# Altium schematic sheet RTL8189_WIFI.SchDoc
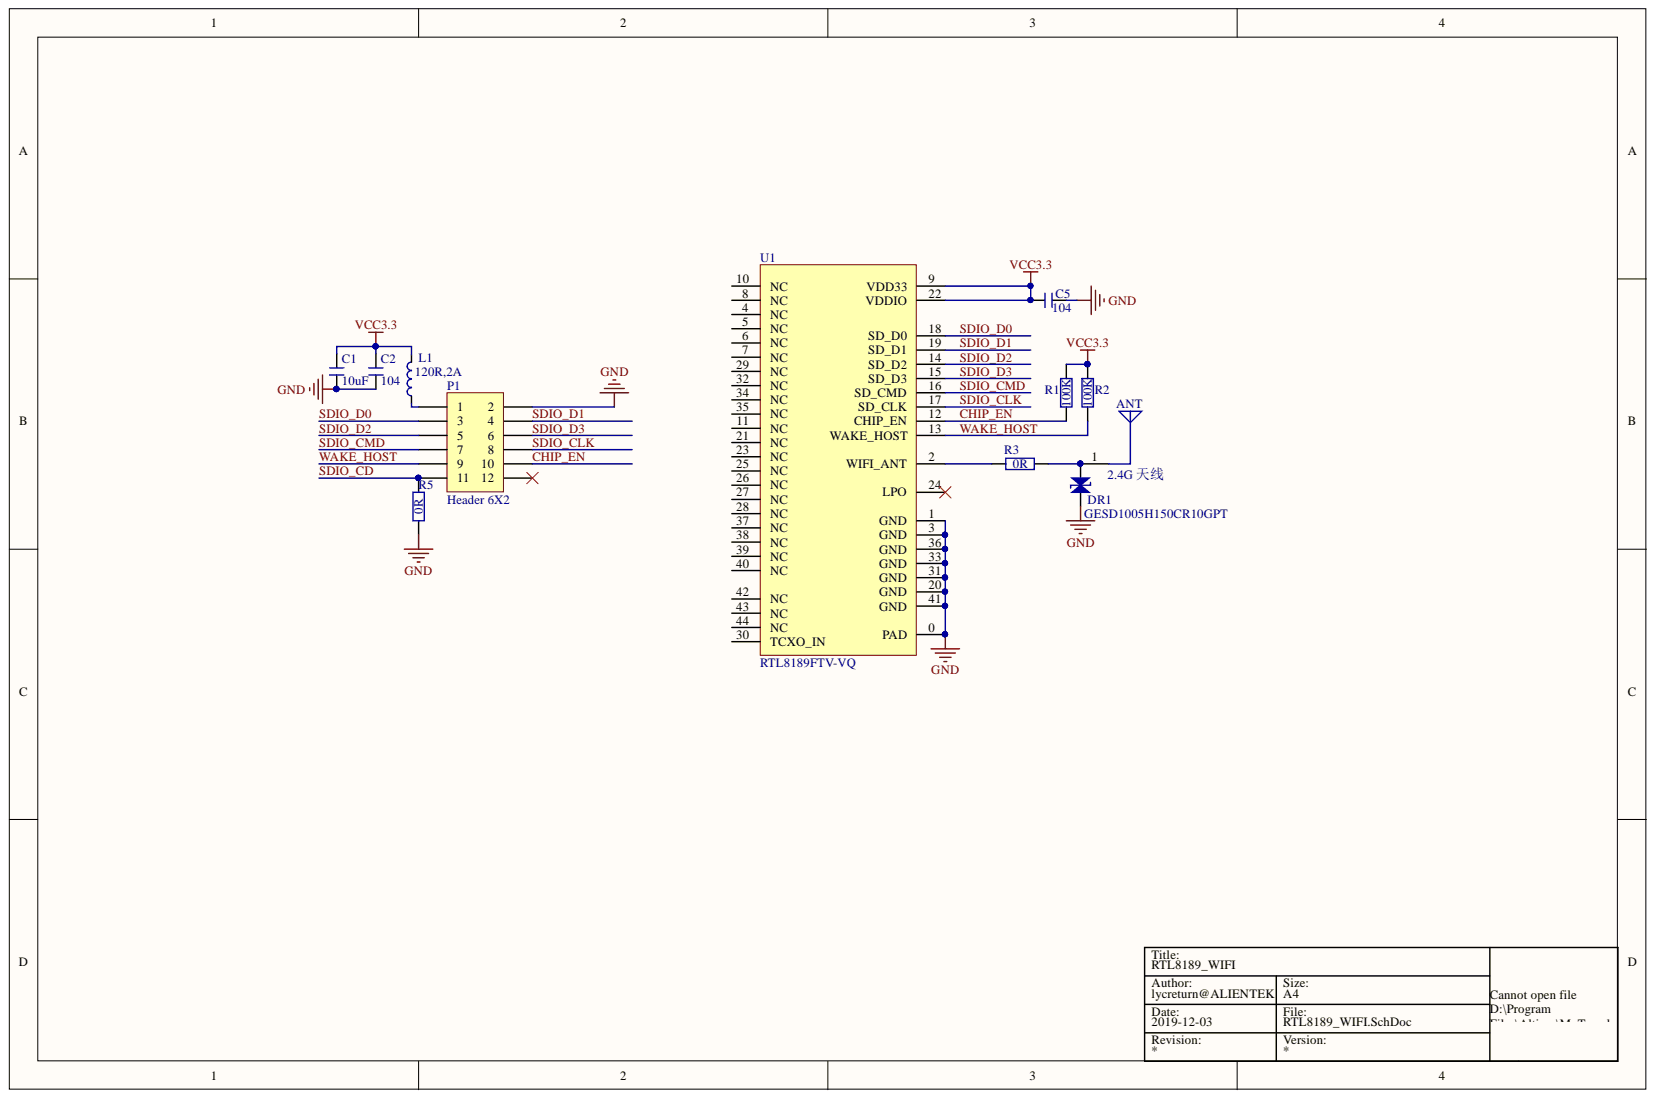
[diagram p0: RTL8189_WIFI.SchDoc]

## Parts
ANT  2.4G 天线  [IRF-2.4GHZ]
C1  10uF  [C 0603]
C2  104  [C 0402]
C5  104  [C 0402]
DR1  GESD1005H150CR10GPT  [L 0402]
L1  120R,2A  [L 0603]
P1  Header 6X2  [HDR2X6]
R1  100K  [R 0402]
R2  100K  [R 0402]
R3  0R  [R 0402]
R5  0R  [R 0402]
U1  RTL8189FTV-VQ  [RTL8189-Module-44P]

## Nets
GND: C1.2 C2.2 C5.2 DR1.2 P1.2 R5.1 U1.0(PAD) U1.1(GND) U1.3(GND) U1.20(GND) U1.31(GND) U1.33(GND) U1.36(GND) U1.41(GND)
VCC3.3: C1.1 C2.1 C5.1 L1.2 R1.2 R2.2 U1.9(VDD33) U1.22(VDDIO)
CHIP_EN: P1.10 R1.1 U1.12(CHIP_EN)
SDIO_CD: P1.11 R5.2
SDIO_CLK: P1.8 U1.17(SD_CLK)
SDIO_CMD: P1.7 U1.16(SD_CMD)
SDIO_D0: P1.3 U1.18(SD_D0)
SDIO_D1: P1.4 U1.19(SD_D1)
SDIO_D2: P1.5 U1.14(SD_D2)
SDIO_D3: P1.6 U1.15(SD_D3)
WAKE_HOST: P1.9 R2.1 U1.13(WAKE_HOST)
NetANT_1: ANT.1 DR1.1 R3.2
NetL1_1: L1.1 P1.1
NetR3_1: R3.1 U1.2(WIFI_ANT)

Not connected: P1 12; U1 4(NC) 5(NC) 6(NC) 7(NC) 8(NC) 10(NC) 11(NC) 21(NC) 23(NC) 24(LPO) 25(NC) 26(NC) 27(NC) 28(NC) 29(NC) 30(TCXO_IN) 32(NC) 34(NC) 35(NC) 37(NC) 38(NC) 39(NC) 40(NC) 42(NC) 43(NC) 44(NC)

## Library data of the parts
ANT = 2.4G 天线: 板载2.4G射频天线; 版权归属: 源创客; BOM名称: 板载2.4G射频天线; Component Kind: Standard; Library Name: 接口类.SchLib; 学习资源: www.ooocke.com
C1 C2 C5 = C: 无极性贴片电容; 版权归属: 源创客; BOM名称: 无极性贴片电容; Component Kind: Standard; 学习资源: www.ooocke.com; Library Name: Lycreturn.IntLib
DR1 = DR: 瞬态电压抑制二极管; 版权归属: 源创客; BOM名称: 瞬态电压抑制二极管; Component Kind: Standard; Library Name: 二极管-整流桥.SchLib; 学习资源: www.ooocke.com
L1 = L: 小功率贴片电感磁珠; 版权归属: 源创客; BOM名称: 小功率贴片电感; Component Kind: Standard; Library Name: 电阻-电容-电感.SchLib; 学习资源: www.ooocke.com
P1 = Header 6X2: Header, 6-Pin, Dual row; Component Kind: Standard; LatestRevisionDate: 17-Jul-2002; LatestRevisionNote: Re-released for DXP Platform.; Library Name: Miscellaneous Connectors.IntLib; Signal Integrity: Connector; Publisher: Altium Limited
R1-R3 R5 = R: 贴片电阻; 版权归属: 源创客; BOM名称: 贴片电阻; Component Kind: Standard; 学习资源: www.ooocke.com
  R3: Library Name: 电阻-电容-电感.SchLib
  R1 R2 R5: Library Name: Lycreturn.IntLib
U1 = RTL8189FTV-VQ: Component Kind: Standard; Description: RTL8189FTV-VQ; Library Name: 模块.SchLib
